# Altium schematic sheet mcu_radio.SchDoc
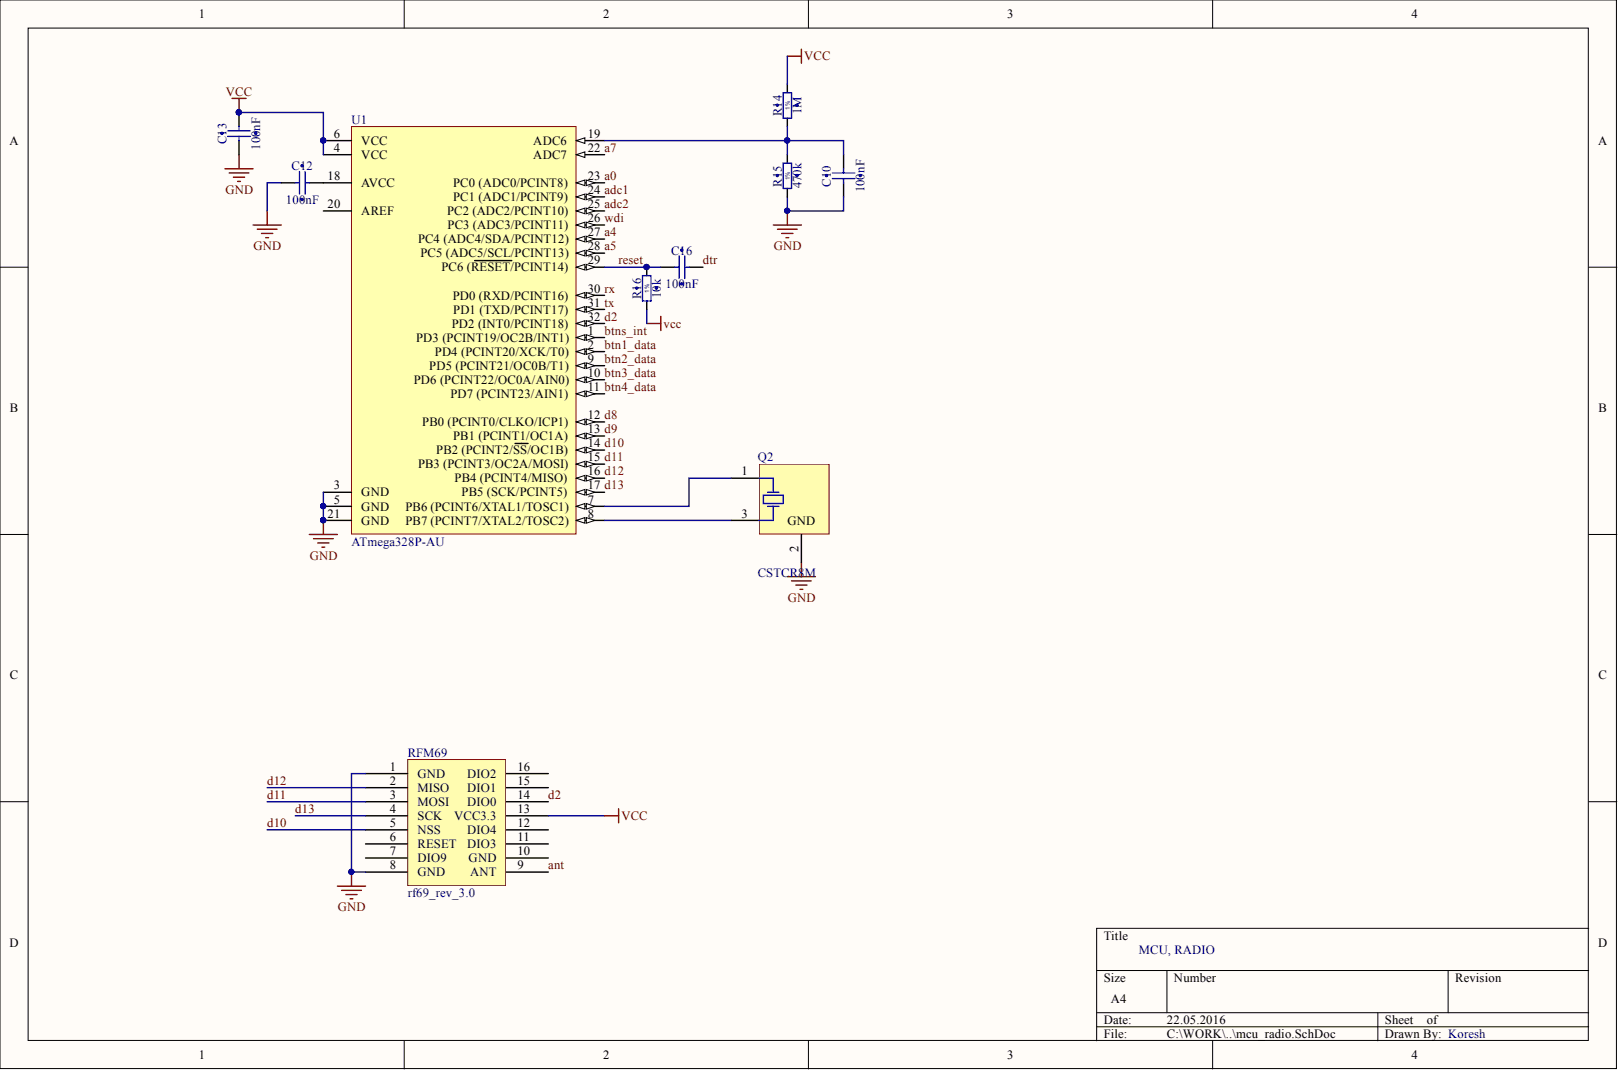
[diagram p0: mcu_radio.SchDoc]

## Parts
C10  100nF  [CAPC1005X55N]
C12  100nF  [CAPC1005X55N]
C13  100nF  [CAPC1005X55N]
C16  100nF  [CAPC1005X55N]
Q2  CSTCR8M
R14  1M  [RESC1005X40N]
R15  470k  [RESC1005X40N]
R16  10k  [RESC1005X40N]
RFM69  rf69_rev_3.0  [rf69_rev3.0]
U1  ATmega328P-AU  [32A_N]

## Nets
GND: C10.1 C12.1 C13.1 Q2.2 R15.1 RFM69.1(GND) RFM69.8(GND) U1.3(GND) U1.5(GND) U1.21(GND)
VCC: C13.2 R14.2 R16.1 RFM69.13(VCC3.3) U1.4(VCC) U1.6(VCC)
A0: U1.23(PC0_(ADC0/PCINT8))
A4: U1.27(PC4_(ADC4/SDA/PCINT12))
A5: U1.28(PC5_(ADC5/SCL/PCINT13))
A7: U1.22(ADC7)
ADC1: U1.24(PC1_(ADC1/PCINT9))
ADC2: U1.25
ANT: RFM69.9(ANT)
BTN1_DATA: U1.2(PD4_(PCINT20/XCK/T0))
BTN2_DATA: U1.9(PD5_(PCINT21/OC0B/T1))
BTN3_DATA: U1.10(PD6_(PCINT22/OC0A/AIN0))
BTN4_DATA: U1.11(PD7_(PCINT23/AIN1))
BTNS_INT: U1.1(PD3_(PCINT19/OC2B/INT1))
D2: RFM69.14(DIO0) U1.32(PD2_(INT0/PCINT18))
D8: U1.12(PB0_(PCINT0/CLKO/ICP1))
D9: U1.13(PB1_(PCINT1/OC1A))
D10: RFM69.5(NSS) U1.14(PB2_(PCINT2/SS/OC1B))
D11: RFM69.3(MOSI) U1.15(PB3_(PCINT3/OC2A/MOSI))
D12: RFM69.2(MISO) U1.16(PB4_(PCINT4/MISO))
D13: RFM69.4(SCK) U1.17(PB5_(SCK/PCINT5))
DTR: C16.2
RESET: C16.1 R16.2 U1.29(PC6_(RESET/PCINT14))
RX: U1.30(PD0_(RXD/PCINT16))
TX: U1.31(PD1_(TXD/PCINT17))
WDI: U1.26(PC3_(ADC3/PCINT11))
NetC10_2: C10.2 R14.1 R15.2 U1.19(ADC6)
NetC12_2: C12.2 U1.18(AVCC)
NetQ2_1: Q2.1 U1.7
NetQ2_3: Q2.3 U1.8

Not connected: RFM69 6(RESET) 7(DIO9) 10(GND) 11(DIO3) 12(DIO4) 15(DIO1) 16(DIO2); U1 20(AREF)

## Library data of the parts
C10 C12 C13 C16 = CC0402_100NF_16V_10%_X5R: Non-polarised capacitor; Manufacturer: GENERIC; Manufacturer1 Part Number: GRM155R61C104KA88D; Part Description: SMD Multilayer Chip Ceramic Capacitor; Database Table Name: Capacitors SMD; TC: X5R; Tolerance: ±10%; Voltage: 16V; Case: 0402; Component Kind: Standard; Component Type: Standard; CreateDate: 10.12.2013; HelpURL: \\cern.ch\dfs\Applications\Altium\Datasheets\CC0402_X5R_MURATA_GRM.pdf; LatestRevisionDate: 10.12.2013; Library Name: Capacitors.DbLib; Manufacturer1 ComponentHeight: 0.55mm; Manufacturer1 Example: MURATA; Manufacturer Part Number: CC0402_100NF_16V_10%_X5R; Mounted: Yes; Part Number: CC0402_100NF_16V_10%_X5R; Pin Count: 2; SMD: Yes; Socket: No; Status: None; Table: Capacitors SMD; Value: 100nF; Author: CERN DEM JLC
Q2 = CMP-0588-00001-1: Ceramic Resonator, 16 MHz with Built-in Capacitance, Thickness Expander Mode, Initial Frequency Tolerance +/- 0.5%, Load Capacity 15 pF, -20 to 80 degC, 3.2 x 1.3 x 1 mm SMD, RoHS, Reel; Manufacturer: Murata; PartNumber: CSTCE16M0V53-R0; Load Capacity: 15 pF; Oscillating Frequency: 16 MHz; Component Kind: Standard; ComponentLink1Description: Manufacturer URL; ComponentLink1URL: http://www.murata.com/; ComponentLink2Description: Datasheet; ComponentLink2URL: http://www.murata.com/products/catalog/pdf/p16e.pdf; DatasheetVersion: Rev. P16E-22; Initial Frequency Tolerance: +/- 0.5%; Initial Tolerance: +/- 0.5%; Library Name: Altium Content Vault; Mounting Technology: Surface Mount; Operating Temperature Range: -20 to 80 degC; PackageDescription: 3-Pin SMD, Body 3.2 x 1.3 mm, Pitch 0.95 mm; PackageReference: CSTCE_V-3; Packing: Reel; RoHS: TRUE
R14 = R0402_1M_1%_0.0625W_100PPM: Resistor - 1%; Manufacturer: GENERIC; Manufacturer1 Part Number: 232270671005L; Part Description: General Purpose Thick Film Chip Resistor; Database Table Name: Resistors SMD; TC: ±100ppm/°C; Case: 0402; Component Kind: Standard; Component Type: Standard; CreateDate: 03.12.2007; HelpURL: \\cern.ch\dfs\Applications\Altium\Datasheets\R0402_Phycomp_RC0402.pdf; LatestRevisionDate: 17.10.2012; Library Name: Resistors.DbLib; Manufacturer1 ComponentHeight: 0.4mm; Manufacturer1 Example: YAGEO PHYCOMP; Manufacturer Part Number: R0402_1M_1%_0.0625W_100PPM; Mounted: Yes; Part Number: R0402_1M_1%_0.0625W_100PPM; Pin Count: 2; Power: 0.0625W; SMD: Yes; Socket: No; Status: Not recommended; Table: Resistors SMD; Tolerance: ±1%; Value: 1M; Author: CERN DEM JLC
R15 = R0402_470K_1%_0.0625W_100PPM: Resistor - 1%; Manufacturer: GENERIC; Manufacturer1 Part Number: 232270674704L; Part Description: General Purpose Thick Film Chip Resistor; Database Table Name: Resistors SMD; TC: ±100ppm/°C; Case: 0402; Component Kind: Standard; Component Type: Standard; CreateDate: 03.12.2007; HelpURL: \\cern.ch\dfs\Applications\Altium\Datasheets\R0402_Phycomp_RC0402.pdf; LatestRevisionDate: 17.10.2012; Library Name: Resistors.DbLib; Manufacturer1 ComponentHeight: 0.4mm; Manufacturer1 Example: YAGEO PHYCOMP; Manufacturer Part Number: R0402_470K_1%_0.0625W_100PPM; Mounted: Yes; Part Number: R0402_470K_1%_0.0625W_100PPM; Pin Count: 2; Power: 0.0625W; SMD: Yes; Socket: No; Status: Not recommended; Table: Resistors SMD; Tolerance: ±1%; Value: 470k; Author: CERN DEM JLC
R16 = R0402_10K_1%_0.0625W_100PPM: Resistor - 1%; Manufacturer: GENERIC; Manufacturer1 Part Number: 232270671003L; Part Description: General Purpose Thick Film Chip Resistor; Database Table Name: Resistors SMD; TC: ±100ppm/°C; Case: 0402; Component Kind: Standard; Component Type: Standard; CreateDate: 03.12.2007; HelpURL: \\cern.ch\dfs\Applications\Altium\Datasheets\R0402_Phycomp_RC0402.pdf; LatestRevisionDate: 17.10.2012; Library Name: Resistors.DbLib; Manufacturer1 ComponentHeight: 0.4mm; Manufacturer1 Example: YAGEO PHYCOMP; Manufacturer Part Number: R0402_10K_1%_0.0625W_100PPM; Mounted: Yes; Part Number: R0402_10K_1%_0.0625W_100PPM; Pin Count: 2; Power: 0.0625W; SMD: Yes; Socket: No; Status: Not recommended; Table: Resistors SMD; Tolerance: ±1%; Value: 10k; Author: CERN DEM JLC
U1 = ATmega328P-AU: 8-bit AVR Microcontroller, 32KB Flash, 1KB EEPROM, 2KB SRAM, 32-pin TQFP, Industrial Grade (-40°C to 85°C); Code_JEDEC: MS-026-ABA; Component Kind: Standard; ComponentLink1Description: Manufacturer URL; ComponentLink1URL: http://www.atmel.com/; ComponentLink2Description: ATmega48A/48PA/88A/88PA/168A/168PA/328/328P Datasheet; ComponentLink2URL: http://www.atmel.com/dyn/resources/prod_documents/doc8271.pdf; ComponentLink3Description: ATmega48A/48PA/88A/88PA/168A/168PA/328/328P Summary; ComponentLink3URL: http://www.atmel.com/dyn/resources/prod_documents/8271S.pdf; DatasheetVersion: revD, May-2011; Library Name: Atmel Microcontroller megaAVR.IntLib; PackageDescription: 32-Lead, 7x7x1.0 mm Body, 0.8mm Lead Pitch, Thin Profile Plastic Quad Flat Package (TQFP); PackageReference: 32A; PackageVersion: revC, Oct-2010
RFM69 = rf69_rev_3.0: Component Kind: Standard; Library Name: rf69.SchLib
